# Altium schematic sheet spoofer.SchDoc
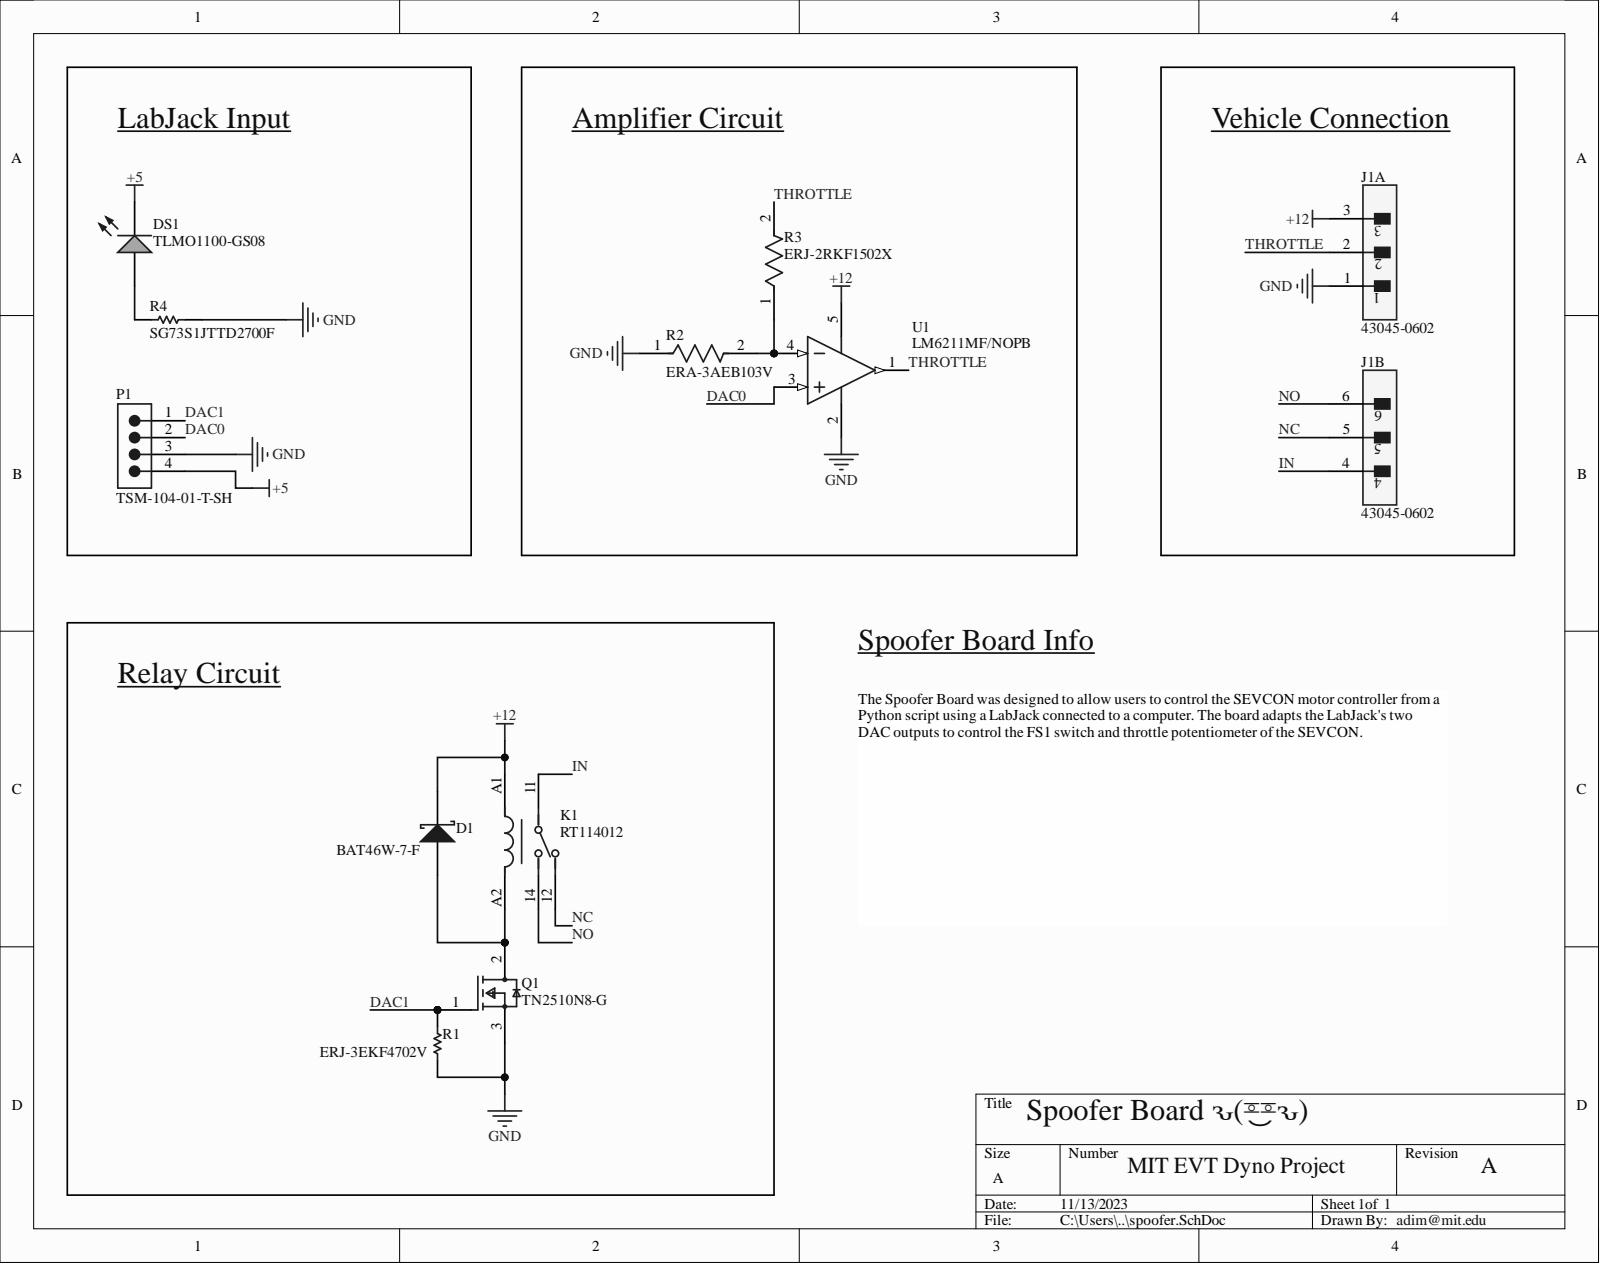
[diagram p0: spoofer.SchDoc]

## Parts
D1  BAT46W-7-F  [DIOD-SOD-123-2_V]
DS1  TLMO1100-GS08  [VISH-TLMX1100-2_V]
J1  43045-0602  [FP-43045-0602-MFG]  (parts A,B)
K1  RT114012  [FP-RT114012-MFG]
P1  TSM-104-01-T-SH  [SMTC-TSM-104-01-X-SH]
Q1  TN2510N8-G  [FP-TN2510N8-G-IPC_C]
R1  ERJ-3EKF4702V  [RESC1608X55X30NL15T15]
R2  ERA-3AEB103V  [FP-ERA3A-IPC_C]
R3  ERJ-2RKF1502X  [FP-ERJ2R-MFG]
R4  SG73S1JTTD2700F  [RESC1608X55X30ML20T10]
U1  LM6211MF/NOPB  [DBV0005A_L]

## Nets
+5: DS1.2 P1.4
+12: D1.2 J1.3 K1.A1 U1.5
GND: J1.1 P1.3 Q1.3 R1.1 R2.1 R4.2 U1.2
DAC0: P1.2 U1.3
DAC1: P1.1 Q1.1 R1.2
IN: J1.4 K1.11
NC: J1.5 K1.12
NO: J1.6 K1.14
THROTTLE: J1.2 R3.2 U1.1
NetD1_1: D1.1 K1.A2 Q1.2
NetDS1_1: DS1.1 R4.1
NetR2_2: R2.2 R3.1 U1.4

## Library data of the parts
D1 = CMP-0303-00004-1: Surface Mount Schottky Barrier Diode, 100 V, -55 to 125 degC, 2-Pin SOD123, RoHS, Tape and Reel; Manufacturer: Diodes Inc; CT@1 V: 12 pF Typ.; IR@1.5 V: 0.3 uA Max.; V(BR)R: 100 V Min.; VF@10 mA: 0.45 V Max.; Component Kind: Standard; ComponentLink1Description: Manufacturer URL; ComponentLink1URL: http://www.diodes.com/; ComponentLink2Description: Datasheet; ComponentLink2URL: http://diodes.com/datasheets/ds30044.pdf; ComponentLink3Description: Package Specification; ComponentLink3URL: http://diodes.com/datasheets/ds30044.pdf; DatasheetVersion: Rev. 16-2; Library Name: Altium Content Vault; Mounting Technology: Surface Mount; Operating Temperature: -55 to 125 degC; PackageDescription: 2-Pin SMD, Body 2.7 x 1.55 mm, Height 1.45 mm; Packing: Tape and Reel; PartNumber: BAT46W-7-F; RoHS: TRUE
DS1 = CMP-1666-00029-1: Orange LED, 20 mA, 2.1 V, -40 to 100 degC, 2-Pin SMD (0603), RoHS, Tape and Reel; Capacitance: -323 f; Forward Current: 150 mA; Forward Voltage: 1 V; Peak Reverse Current: 5 uA; Recovery Time: 4 ns; Component Kind: Standard; ComponentLink1Description: Manufacturer URL; ComponentLink1URL: http://www.vishay.com/; ComponentLink2Description: Datasheet; ComponentLink2URL: http://download.siliconexpert.com/pdfs/2016/8/7/12/43/26/667/vsh_/manual/379263299541529tlmb1100.pdf; DatasheetVersion: Rev. 2.7, 03/2016; Height: 1 mm; Length: 1.8 mm; Library Name: Altium Content Vault; Max Operating Temperature: 150 degC; Min Operating Temperature: -55 degC; Mount: Surface Mount; Package Quantity: 3000; PackageDescription: 2-Pin Surface Mount Device, Body 1.6 x 0.8 mm; PackageReference: 0603; Packaging: Tape and Reel; Pins: 2; Radiation Hardening: No; REACH SVHC: No SVHC; RoHSCompliant: true; Width: 1.35 mm
J1 = CMP-04776-000581-1: CONN HEADER R/A 6POS 3MM; Contact Resistance: 10mR; Current Rating: 5A; Gender: Male; Glow Wire Compliant: Compliant; Insulation Resistance: 1GR; Max Voltage Rating (AC): 250V; Max Voltage Rating (DC): 250.25kV; Number of Circuits: 6; Color: Black; Component Kind: Standard; Connector Type: Header; Contact Finish - Mating: GOLD; Contact Material: Brass; Contact Plating: Gold; Contact Shape: Square; Contact Type: Male Pin; Depth: 12.2mm; Diameter: 3mm; Fastening Type: Ramp; Flammability Rating: UL94 V-0; Halogen Free: Low Halogen; Height: 8.77mm; Housing Color: Black; Housing Material: Polymer; Insulation Color: Black; Insulation Material: Polymer; Lead Free: Lead Free; Length: 13.15mm; Library Name: Altium Content Vault; Max Current Rating: 5A; Max Operating Temperature: 105°C; Min Operating Temperature: -40°C; Mount: Through Hole; Number of Contacts: 6; Number of Positions: 6; Number of Rows: 2; Orientation: Right Angle; Package Quantity: 1728; Packaging: Tray; Pitch: 3mm; Radiation Hardening: No; RoHS Compliant: Yes; Row Spacing: 3mm; Series: Micro-Fit 3.0; Termination: Solder; Voltage Rating: 250V; Voltage Rating (DC): 250V
K1 = CMP-03395-000068-1: RELAY GEN PURPOSE SPDT 12A 12V; Manufacturer: TE Connectivity; Coil Power: 400mW; Coil Resistance: 360Ω; Coil Type: Non-Latching; Number Of Contacts: 1; Ambient Temperature Range High: +85°C; Ambient Temperature Range Low: -40°C; Automotive: No; Category: Relay; Coil Voltage: 12V; Component Kind: Standard; ComponentLink2Description: Datasheet; ComponentLink2URL: https://www.te.com/commerce/DocumentDelivery/DDEController?Action=showdoc&DocId=Data+Sheet%7FRT1%7F0219%7Fpdf%7FEnglish%7FENG_DS_RT1_0219.pdf%7F1419108-1; Current Rating: 12A; Device Class L1: Electromechanical; Device Class L2: Relays; Device Class L3: Power Relays; Digikey Description: RELAY GEN PURPOSE SPDT 12A 12V; Height: 15.7mm; Lead Free: Yes; Library Name: Altium Content Vault; Manufacturer Part Number: RT114012; Mouser Description: General Purpose Relays RT114012; Octopart: https://octopart.com/rt114012-te+connectivity-39636422; Package: RT114012; RoHS: TRUE; Throw Configuration: SPDT
P1 = CMP-1024-00223-1: 0.025" SQ Post Header, Surface Mount, Horizontal, -55 to 105 degC, 2.54 mm Pitch, 4-Pin, Male, RoHS; Manufacturer: Samtec; Pitch: 2.54 mm; Component Kind: Standard; ComponentLink1Description: Manufacturer URL; ComponentLink1URL: http://www.samtec.com; ComponentLink2Description: Datasheet; ComponentLink2URL: http://www.samtec.com/documents/webfiles/pdf/TSM.PDF; ComponentLink3Description: Package Specification; ComponentLink3URL: http://www.samtec.com/documents/webfiles/cpdf/TSM-1XX-XX-XXX-SH-XX-XXX-XX-MKT1.pdf; DatasheetVersion: F-214; Library Name: Altium Content Vault; Mounting Technology: Surface Mount; Orientation: Horizontal; PackageDescription: Terminal Strip Single Surface Mount; PackageReference: TSM-104-01-X-SH; PackageVersion: CD, 7/1991; PartNumber: TSM-104-01-T-SH; RoHS: True; Temperature Range: -55 to 105 degC
Q1 = CMP-06369-000016-1: MOSFET N-CH 100V 730MA TO243AA; Drain to Source Resistance: 1.5R; Element Configuration: Single; Fall Time: 10ns; Gate to Source Voltage (Vgs): 20V; Input Capacitance: 125pF; Max Power Dissipation: 1.6W; Number of Channels: 1; Polarity: N-CHANNEL; Turn-Off Delay Time: 20ns; Case/Package: TO-243AA; Component Kind: Standard; Contact Plating: Tin; Continuous Drain Current (ID): 730mA; Drain to Source Breakdown Voltage: 100V; Height: 1.6mm; Lead Free: Lead Free; Length: 4.6mm; Library Name: Altium Content Vault; Max Operating Temperature: 150°C; Min Operating Temperature: -55°C; Mount: Surface Mount; Number of Elements: 1; Number of Pins: 4; Package Quantity: 2000; Packaging: Tape and Reel; Power Dissipation: 1.6W; Radiation Hardening: No; Rds On Max: 1.5R; Rise Time: 10ns; Series: -; Turn-On Delay Time: 10ns; Weight: 0.001862oz; Width: 2.6mm
R1 = CMP-2000-00523-2: Chip Resistor, 47 KOhm, +/- 1%, 0.1 W, -55 to 155 degC, 0603 (1608 Metric), RoHS, Tape and Reel; Case\Package: 0603; Forward Current: 20 mA; Forward Voltage: 2.1 V; Illumination Colour: Red; Lens Style: Clear; Luminous Intensity: 63 mcd; Nominal Wavelength: 639 nm; Power Rating: 90 mW; Viewing Angle: 160 degrees; Case Code (Imperial): 0603; Case Code (Metric): 1608; Colour: Red; Component Kind: Standard; ComponentLink1Description: Manufacturer URL; ComponentLink1URL: http://www.panasonic.com/; ComponentLink2Description: Datasheet; ComponentLink2URL: http://industrial.panasonic.com/cdbs/www-data/pdf/RDA0000/AOA0000C86.pdf; DatasheetVersion: Apr-15; Height: 0.6 mm; Length: 1.6 mm; Library Name: Altium Content Vault; Max Operating Temperature: 100 degC; Min Operating Temperature: -40 degC; Mount: Surface Mount; Nominal Vf: 2.1 V; Package Quantity: 3000; PackageDescription: 2-Pin Surface Mount Device, Body 1.6 x 0.85 mm; PackageReference: 0603; Packaging: Tape and Reel; Pins: 2; Radiation Hardening: No; REACH SVHC: No SVHC; RoHSCompliant: true; Width: 0.8 mm
R2 = CMP-2000-00874-2: RES SMD 10K OHM 0.1% 1/10W 0603; Max Power Dissipation: 100mW; Resistance: 10kR; Temperature Coefficient: 25ppm/°C; Voltage Rating: 75V; Case Code (Imperial): 0603; Case Code (Metric): 1608; Case/Package: 0603; Component Kind: Standard; Construction: Rectangular; Contact Plating: Tin; Depth: 0.8mm; Height: 0.45mm; Lead Free: Lead Free; Length: 1.6mm; Library Name: Altium Content Vault; Max Operating Temperature: 155°C; Military Standard: Not; Min Operating Temperature: -55°C; Mount: Surface Mount; Number of Pins: 2; Number of Terminations: 2; Package Quantity: 5000; Packaging: Tape and Reel; Power Rating: 100mW; Radiation Hardening: No; REACH SVHC: No SVHC; Resistance Tolerance: 0.1%; Resistor Type: Thin Film; RoHS Compliant: Yes; Series: ERA-3A; Size Code: 0603; Technology: METAL FILM; Termination: SMD/SMT; Tolerance: 0.1%; Voltage Rating (DC): 75V; Width: 0.8mm
R3 = CMP-2002-00694-4: RES SMD 15K OHM 1% 1/10W 0402; Manufacturer: Panasonic; Automotive Grade: Grade 0; Power Rating: 0.1W; Resistance: 15kΩ; Temperature Coefficient: 100ppm/°C; Value: 15kΩ; Voltage Rating: 50V; Automotive: Yes; Category: Res; Component Kind: Standard; ComponentLink2Description: Datasheet; ComponentLink2URL: https://industrial.panasonic.com/cdbs/www-data/pdf/RDA0000/AOA0000C304.pdf; Composition: Thick Film; Device Class L1: Passive Components; Device Class L2: Resistors; Device Class L3: Chip SMD Resistors; Digikey Description: RES SMD 15K OHM 1% 1/10W 0402; Footprint Url: https://b2b-api.panasonic.eu/file_stream/pids/fileversion/1180; Height: 0.4mm; Lead Free: Yes; Library Name: Altium Content Vault; Manufacturer Part Number: ERJ-2RKF1502X; Mouser Description: Res Thick Film 1.0 x 0.5 mm 15K Ohm 1% 0.1W(1/10W) 100ppm/ C Molded SMD Punched Carrier T/R; Octopart: https://octopart.com/erj-2rkf1502x-panasonic-55404985; Package: ERJ2R; RoHS: TRUE; Series: ERJ; Temperature: -55°C to +155°C; Temperature Range High: +155°C; Temperature Range Low: -55°C; Tolerance: 1%
R4 = CMP-2000-01816-1: Manufacturer: KOA Speer; Component Kind: Standard; Library Name: Altium Content Vault; Part Number: SG73S1JTTD2700F
U1 = CMP-0055-01295-3: Low Noise, RRO Operational Amplifier with CMOS Input and 24V Operation, 5-pin SOT-23, Pb-Free; Manufacturer: Texas Instruments; CompType: Amplifiers; PackageTopMarking: AT1A*XTT; PowerWise: Y; Component Kind: Standard; ComponentLink1Description: Datasheet URL; ComponentLink1URL: http://www.ti.com/general/docs/lit/getliterature.tsp?genericPartNumber=LM6211&fileType=pdf; Library Name: Altium Content Vault; Package Reference: DBV0005A; PackageDescription: Molded SOT-23, .115 x .063 x .040 in Body, 5 Lead, .0375 in Pitch; PinCount: 5; RoHS: Y
